# Altium schematic sheet PowerCircuit_24V.SchDoc(PowerCircuit_24V)
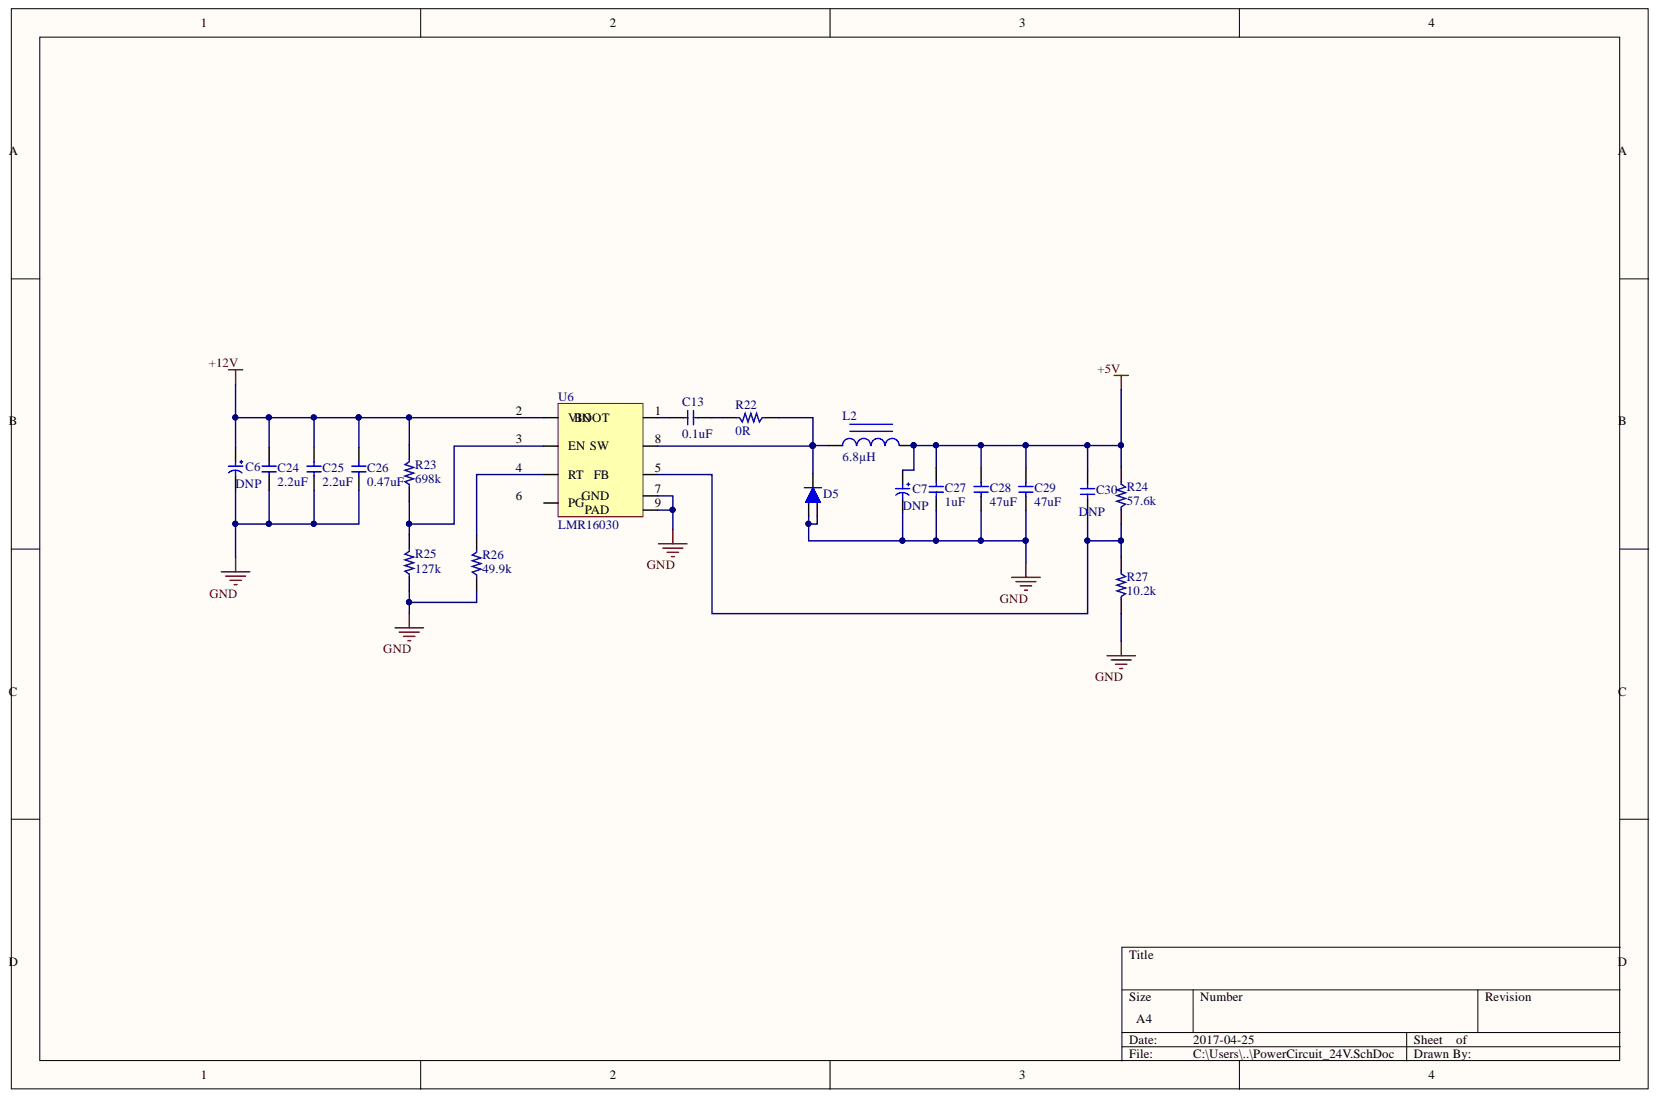
[diagram p0: PowerCircuit_24V.SchDoc]

## Parts
C6  value DNP  [Cap 6.3mm dia 5.4mm Height]  (hidden comment: 47uF, 25V)
C7  value DNP  [Cap 6.3mm dia 5.4mm Height]  (hidden comment: 47uF, 25V)
C13  value 0.1uF  [0603]  (hidden comment: Generic Capacitor)
C24  value 2.2uF  [Cap 1210]  (hidden comment: Generic Capacitor)
C25  value 2.2uF  [Cap 1210]  (hidden comment: Generic Capacitor)
C26  value 0.47uF  [0805]  (hidden comment: Generic Capacitor)
C27  value 1uF  [0805]  (hidden comment: Generic Capacitor)
C28  value 47uF  [Cap 1210]  (hidden comment: Generic Capacitor)
C29  value 47uF  [Cap 1210]  (hidden comment: Generic Capacitor)
C30  Digikey: DNP  [0603]  (hidden comment: Generic Capacitor)
D5  DIODE SCHOTTKY 60V 5A  [TO277A]  (value not drawn)
L2  Inductance: 6.8µH  [7447714068]  (hidden comment: FIXED IND 6.8UH 5.2A)
R22  value 0R  [0603]  (hidden comment: Resistor)
R23  value 698k  [0603]  (hidden comment: Resistor)
R24  value 57.6k  [0603]  (hidden comment: Resistor)
R25  value 127k  [0603]  (hidden comment: Resistor)
R26  value 49.9k  [0603]  (hidden comment: Resistor)
R27  value 10.2k  [0603]  (hidden comment: Resistor)
U6  LMR16030  [LMR16030]

## Nets
+5V: C7.1 C27.1 C28.1 C29.1 C30.1 L2.0 R24.1
+12V: C6.1 C24.1 C25.1 C26.1 R23.2 U6.2(VIN)
GND: C6.2 C7.2 C24.2 C25.2 C26.2 C27.2 C28.2 C29.2 D5.1 D5.2 R25.1 R26.1 R27.2 U6.7 U6.9
NetC13_1: C13.1 U6.1
NetC13_2: C13.2 R22.2
NetC30_2: C30.2 R24.2 R27.1 U6.5
NetD5_3: D5.3 L2.1 R22.1 U6.8
NetR23_1: R23.1 R25.2 U6.3(EN_SW)
NetR26_2: R26.2 U6.4(RT)

Not connected: U6 6(PG)

## Library data of the parts
C6 C7 = 865080443007: Polorised capacitor 47uF, 25V; Component Kind: Standard; Library Name: PowerCircuit.SchLib; Supplier: Digikey; Supplier PN: 732-8435-1-ND
C13 C24-C29 = Capacitor: Component Kind: Standard; Description: Generic capacitor; Library Name: PowerCircuit.SchLib
  C13: Digikey: 490-1532-1-ND
  C24 C25: Digikey: 490-3385-1-ND
  C26: Digikey: 490-3326-1-ND
  C27: Digikey: 490-6484-1-ND
  C28 C29: Digikey: 490-3888-1-ND
C30 = Capacitor: Value: 27pF; Component Kind: Standard; Description: Generic capacitor; Library Name: PowerCircuit.SchLib
D5 = SS5P6-M3/86A: DIODE SCHOTTKY 60V 5A TO277A; Manufacturer: Vishay Semiconductor Diodes Division; Capacitance @ Vr, F: 200pF @ 4V, 1MHz; Current - Reverse Leakage @ Vr: 150µA @ 60V; Speed: Fast Recovery =< 500ns, > 200mA (Io); Voltage - Forward (Vf) (Max) @ If: 690mV @ 5A; Component Kind: Standard; ComponentLink1Description: http://www.vishay.com/docs/88988/ss5p6.pdf; ComponentLink1URL: http://www.vishay.com/docs/88988/ss5p6.pdf; Current - Average Rectified (Io): 5A; Diode Type: Schottky; Library Name: PowerCircuit.SchLib; Manufacturer Part Number: SS5P6-M3/86A; Mounting Type: Surface Mount; Operating Temperature - Junction: -55°C ~ 150°C; Package / Case: TO-277, 3-PowerDFN; Packaging: Cut Tape (CT); Part Status: Active; Pricing 1: 1=0.92, 10=0.795, 25=0.7424, 100=0.5937, 250=0.55132, 500=0.4665 (CAD); Reverse Recovery Time (trr): -; Supplier 1: Digi-Key; Supplier Device Package: TO-277A (SMPC); Supplier Part Number 1: SS5P6-M3/86AGICT-ND; Voltage - DC Reverse (Vr) (Max): 60V
L2 = 7447714068: FIXED IND 6.8UH 5.2A 22 MOHM SMD; Manufacturer: Wurth Electronics Inc.; Current - Saturation: 5.8A; DC Resistance (DCR): 22 mOhm Max; Frequency - Self Resonant: 23.5MHz; Frequency - Test: 100kHz; Material - Core: Ferrite; Shielding: Shielded; Tolerance: ±30%; Type: Wirewound; Component Kind: Standard; ComponentLink1Description: http://katalog.we-online.de/pbs/datasheet/7447714068.pdf; ComponentLink1URL: http://katalog.we-online.de/pbs/datasheet/7447714068.pdf; Current Rating: 5.2A; Height - Seated (Max): 0.197" (5.00mm); Library Name: PowerCircuit.SchLib; Manufacturer Part Number: 7447714068; Mounting Type: Surface Mount; Operating Temperature: -40°C ~ 125°C; Package / Case: Nonstandard; Packaging: Cut Tape (CT); Part Status: Active; Pricing 1: 1=4.17, 10=3.651, 50=3.3904, 100=3.26, 250=3.12952 (CAD); Q @ Freq: -; Ratings: -; Size / Dimension: 0.394" L x 0.394" W (10.00mm x 10.00mm); Supplier 1: Digi-Key; Supplier Device Package: -; Supplier Part Number 1: 732-4108-1-ND
R22-R27 = Resistor: Generic Resistor; Component Kind: Standard; Library Name: PowerCircuit.SchLib
  R22: Digikey: 541-49.9HCT-ND
  R23: Digikey: 541-698KHCT-ND
  R24: Digikey: 541-57.6KHCT-ND
  R25: Digikey: 541-127KHCT-ND
  R26: Digikey: 541-49.9KHCT-ND
  R27: Digikey: 541-10.2KHCT-ND
U6 = LMR16030: IC REG BUCK ADJ 3A 8SOPWRPD; Manufacturer: Texas Instruments; Manufacturer Part Number: LMR16030PDDA; Frequency - Switching: 200kHz ~ 2.5MHz; Function: Step-Down; Number of Outputs: 1; Output Configuration: Positive; Output Type: Adjustable; Synchronous Rectifier: No; Voltage - Input (Max): 60V; Voltage - Input (Min): 4.3V; Voltage - Output (Max): 50V; Voltage - Output (Min/Fixed): 0.8V; Component Kind: Standard; ComponentLink1Description: http://www.ti.com/lit/ds/symlink/lmr16030.pdf; ComponentLink1URL: http://www.ti.com/lit/ds/symlink/lmr16030.pdf; Current - Output: 3A; Library Name: PowerCircuit.SchLib; Mounting Type: Surface Mount; Operating Temperature: -40°C ~ 125°C (TJ); Package / Case: 8-PowerSOIC (0.154", 3.90mm Width); Packaging: Tube; Part Status: Active; Pricing 1: 1=8.44, 10=7.577, 100=6.2087, 500=5.28538, 1000=4.45755 (CAD); Supplier 1: Digi-Key; Supplier Device Package: 8-SO PowerPad; Supplier Part Number 1: 296-44513-5-ND; Topology: Buck
